# Altium schematic sheet ADC.SchDoc(ADC)
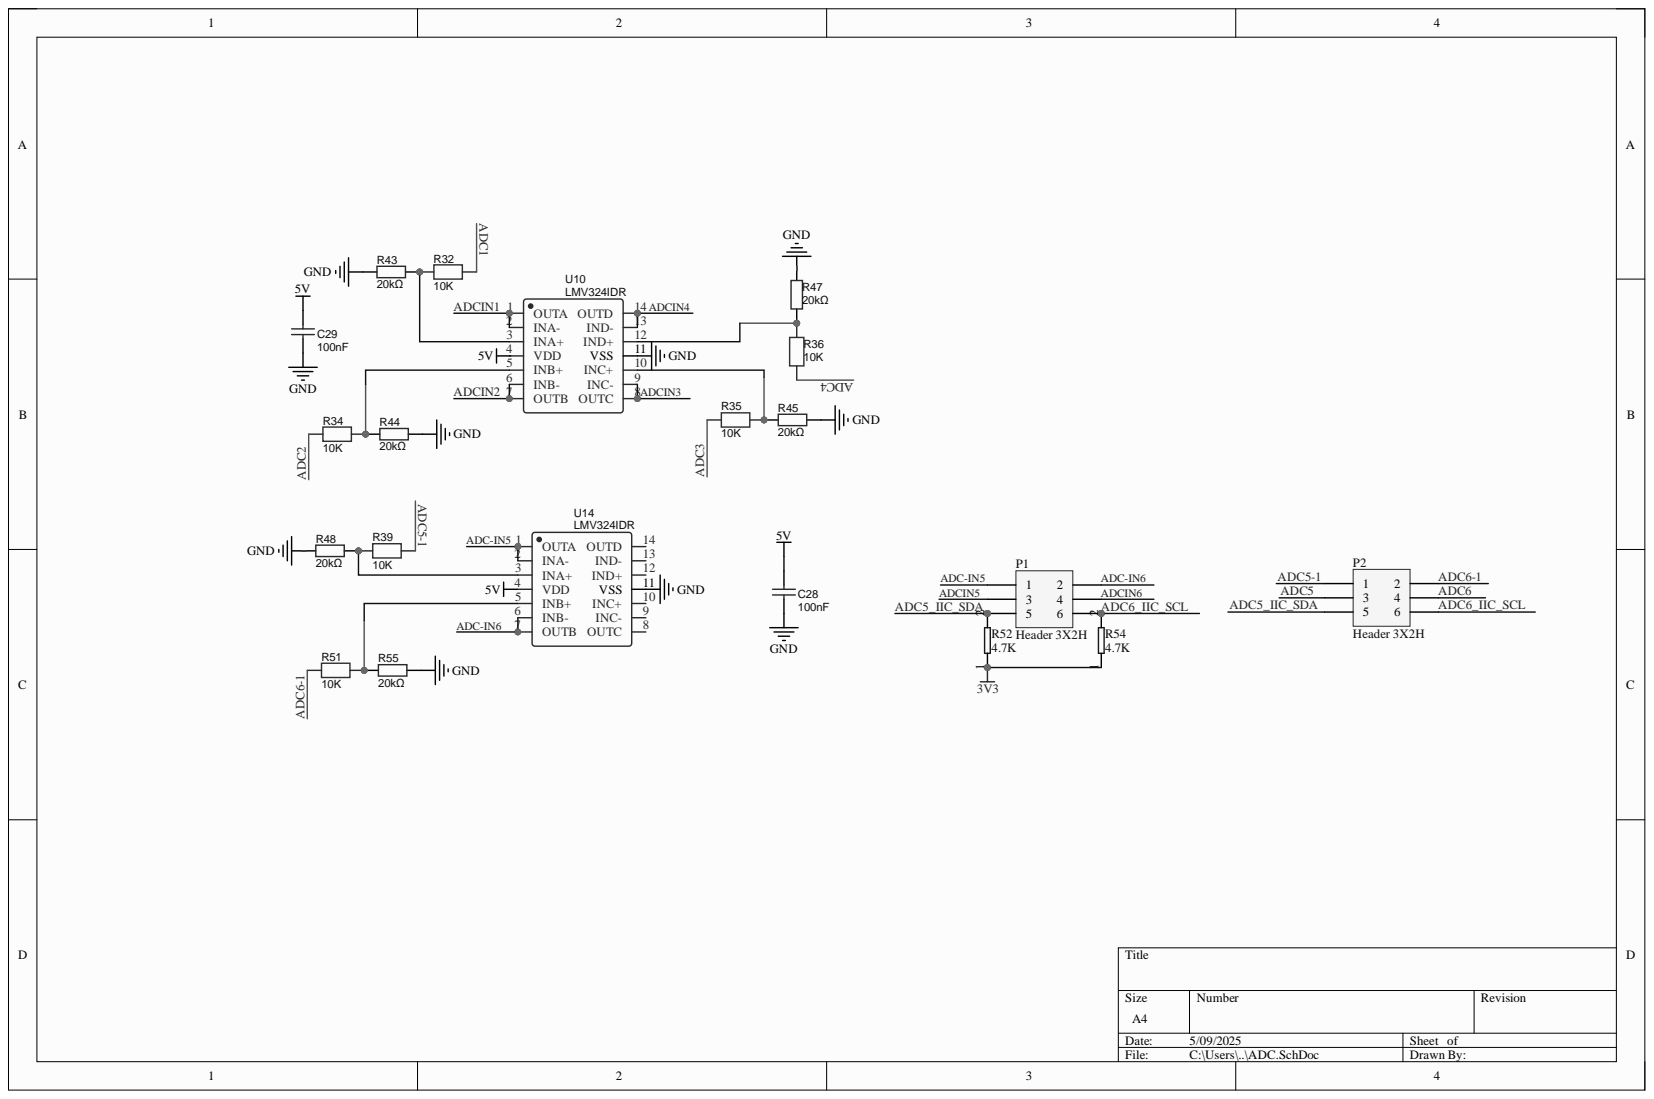
[diagram p0: ADC.SchDoc]

## Parts
C28  100nF  [C0603]
C29  100nF  [C0603]
P1  Header 3X2H  [236PHDR-TH_6P-P2.54-V-M-R2-C3-S2.54]
P2  Header 3X2H  [236PHDR-TH_6P-P2.54-V-M-R2-C3-S2.54]
R32  10K  [R0603]
R34  10K  [R0603]
R35  10K  [R0603]
R36  10K  [R0603]
R39  10K  [R0603]
R43  20kΩ  [R0603]
R44  20kΩ  [R0603]
R45  20kΩ  [R0603]
R47  20kΩ  [R0603]
R48  20kΩ  [R0603]
R51  10K  [R0603]
R52  4.7K  [R0603]
R54  4.7K  [R0603]
R55  20kΩ  [R0603]
U10  LMV324IDR  [SOIC-14_L8.7-W3.9-P1.27-LS6.0-BL]
U14  LMV324IDR  [SOIC-14_L8.7-W3.9-P1.27-LS6.0-BL]

## Nets
3V3: R52.1 R54.1
5V: C28.2 C29.2 U14.4(VDD)
GND: C28.1 C29.1 R43.1 R44.1 R45.1 R47.1 R48.1 R55.1 U10.11(VSS) U14.11(VSS)
ADC1: R32.2
ADC2: R34.2
ADC3: R35.2
ADC4: R36.2
ADC5: P2.3
ADC5-1: P2.1 R39.2
ADC5_IIC_SDA: P1.5 P2.5 R52.2
ADC6: P2.4
ADC6-1: P2.2 R51.2
ADC6_IIC_SCL: P1.6 P2.6 R54.2
ADC-IN5: P1.1 U14.1(OUTA) U14.2(INA-)
ADC-IN6: P1.2 U14.6(INB-) U14.7(OUTB)
ADCIN1: U10.1(OUTA) U10.2(INA-)
ADCIN2: U10.6(INB-) U10.7(OUTB)
ADCIN3: U10.8(OUTC) U10.9(INC-)
ADCIN4: U10.13(IND-) U10.14(OUTD)
ADCIN5: P1.3
ADCIN6: P1.4
NetR32_1: R32.1 R43.2 U10.3(INA+)
NetR34_1: R34.1 R44.2 U10.5(INB+)
NetR35_1: R35.1 R45.2 U10.10(INC+)
NetR36_1: R36.1 R47.2 U10.12(IND+)
NetR39_1: R39.1 R48.2 U14.3(INA+)
NetR51_1: R51.1 R55.2 U14.5(INB+)

Not connected: U10 4(VDD); U14 8(OUTC) 9(INC-) 10(INC+) 12(IND+) 13(IND-) 14(OUTD)

## Library data of the parts
C28 C29 = 100nF: Manufacturer: SAMSUNG; Manufacturer Part: CL10A106MO8NQNC; nameAlias: Capacitance; Component Kind: Standard; JLCPCB Part Class: Extended Part; spicePre: C; spiceSymbolName: CL10A106MO8NQNC; Supplier: LCSC; Supplier Part: C92487
P1 P2 = Header 3X2H: Header, 3-Pin, Dual row, Right Angle; Component Kind: Standard; LatestRevisionDate: 17-Jul-2002; LatestRevisionNote: Re-released for DXP Platform.; Library Name: Miscellaneous Connectors.IntLib; Signal Integrity: Connector; Publisher: Altium Limited
R32 R34-R36 R39 R51 = 10K: Manufacturer: YAGEO; Manufacturer Part: RC0603FR-0710KL; Contributor: TheJun; Component Kind: Standard; spicePre: R; spiceSymbolName: R_0603_EU; Supplier: LCSC; Supplier Part: C98220
R43-R45 R47 R48 R55 = 20kΩ: Manufacturer: UNI-ROYAL(厚声); Manufacturer Part: 0603WAF2002T5E; nameAlias: Value; Component Kind: Standard; JLCPCB Part Class: Basic Part; spicePre: R; spiceSymbolName: 0603WAF2002T5E; Supplier: LCSC; Supplier Part: C4184
R52 R54 = Res: Component Kind: Standard; Library Name: CZ 3D.IntLib
U10 U14 = LMV324IDR_C5342380: Manufacturer: TECH PUBLIC(台舟); Contributor: lcsc; Component Kind: Standard; JLCPCB Part Class: Extended Part; Manufacturer Part: LMV324IDR; spicePre: U; spiceSymbolName: LMV324IDR_C5342380; Supplier: LCSC; Supplier Part: C5342380
